# Altium schematic sheet 03_UART_232.SchDoc
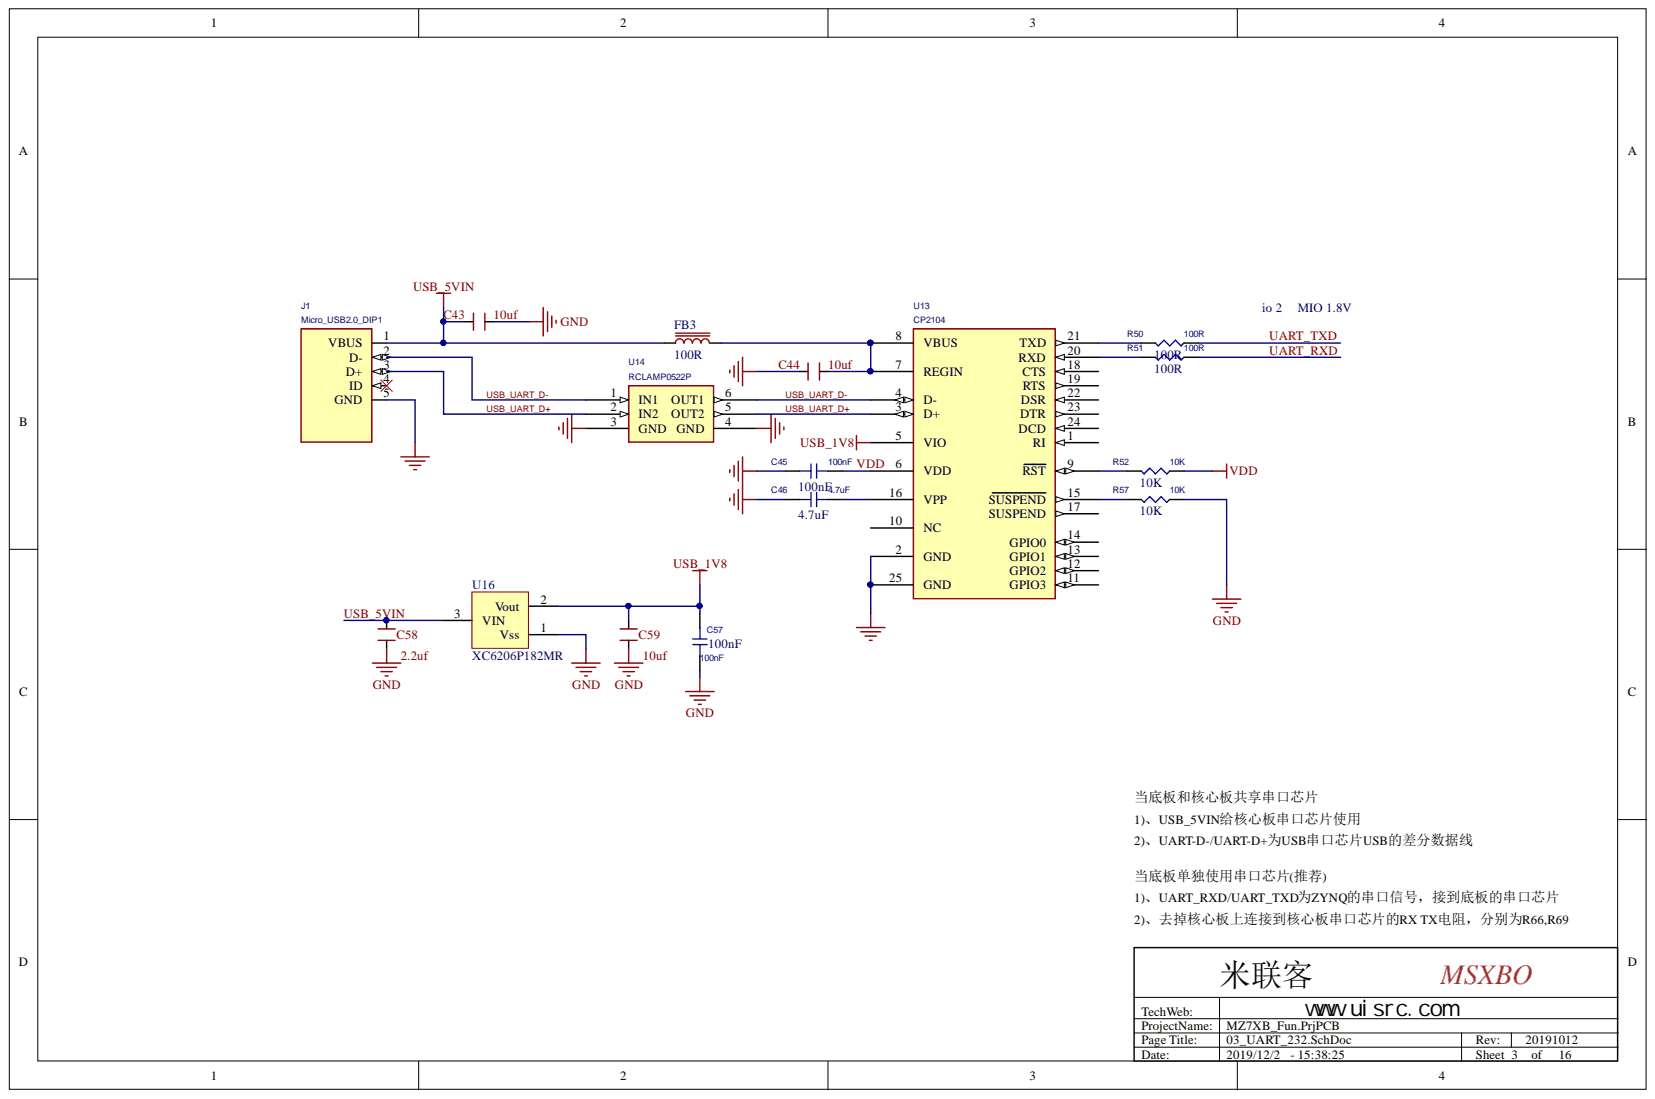
[diagram p0: 03_UART_232.SchDoc]

## Parts
C43  10uf  [CAP0603]
C44  10uf  [CAP0603]
C45  100nF  [C0603]
C46  4.7uF  [C0603]
C57  100nF  [C0603]
C58  2.2uf  [CAP0402]
C59  10uf  [CAP0603]
FB3  100R  [CAP0603]
J1  Micro_USB2.0_DIP1  [Micro_USB2_0_SMD]
R50  100R  [R0402]
R51  100R  [R0402]
R52  10K  [R0603]
R57  10K  [R0603]
U13  CP2104  [QFN24_50P4X4X1]
U14  RCLAMP0522P  [SLP1610P4_6]
U16  XC6206P182MR  [SOT23]

## Nets
GND: C43.1 C44.2 C45.1 C46.1 C57.1 C58.1 C59.1 J1.5(GND) R57.2 U13.2(GND) U13.25(GND) U14.3(GND) U14.4(GND) U16.1
USB_1V8: C57.2 C59.2 U13.5(VIO) U16.2
UART_RXD: R51.2
UART_TXD: R50.2
USB_5VIN: C43.2 C58.2 FB3.1 J1.1(VBUS) U16.3
USB_UART_D+: J1.3(D+) U13.3(D+) U14.2(IN2) U14.5(OUT2)
USB_UART_D-: J1.2(D-) U13.4(D-) U14.1(IN1) U14.6(OUT1)
VDD: C45.2 R52.2 U13.6(VDD)
NetC44_1: C44.1 FB3.2 U13.7(REGIN) U13.8(VBUS)
NetC46_2: C46.2 U13.16(VPP)
NetR50_1: R50.1 U13.21(TXD)
NetR51_1: R51.1 U13.20(RXD)
NetR52_1: R52.1 U13.9(RST)
NetR57_1: R57.1 U13.15(SUSPEND)

Not connected: J1 4(ID); U13 1(RI) 10(NC) 11(GPIO3) 12(GPIO2) 13(GPIO1) 14(GPIO0) 17(SUSPEND) 18(CTS) 19(RTS) 22(DSR) 23(DTR) 24(DCD)

## Library data of the parts
C43 C44 C58 C59 = CAPACITOR: Generic Capacitor; Component Kind: Standard; Library Name: Digilent.IntLib
C45 C46 C57 = CAP1: CURRENT: CIMAX; DIST: FLAT; KNEE: CBMAX; MAX_TEMP: CTMAX; PSpiceTemplate: C^@REFDES %1 %2 ?TOLERANCE|C^@REFDES| @VALUE ?IC/IC=@IC/ TC=@TC1,@TC2 ?TOLERANCE|\n.model C^@REFDES CAP C=1 DEV=@TOLERANCE% TC1=@TC1 TC2=@TC2 VC1=@VC1 VC2=@VC2|; SLOPE: CSMAX; TC1: 0; VOLTAGE: CMAX; Component Kind: Standard; Simulation: CAP1; TC2: 0; VC1: 0; VC2: 0
  C45 C57: Value: 100nF
  C46: Value: 4.7uF
FB3 = Ferrite Bead: Generic Ferrite Bead; Value: 600; Component Kind: Standard; Library Name: M7Z100.IntLib
J1 = Micro_USB2_0.0_DIP1: Component Kind: Standard
R50-R52 R57 = RES1: DIST: FLAT; MAX_TEMP: RTMAX; POWER: RMAX; PSpiceTemplate: R^@REFDES %1 %2 ?TOLERANCE|R^@REFDES| @VALUE TC=@TC1,@TC2 ?TOLERANCE|\n.model R^@REFDES RES R=1 DEV=@TOLERANCE% TC1=@TC1 TC2=@TC2|; SLOPE: RSMAX; TC1: 0; VOLTAGE: RVMAX; Component Kind: Standard; Simulation: RES1; TC2: 0
  R50 R51: Value: 100R
  R52 R57: Value: 10K
U16 = XC6206P332MR: Component Kind: Standard; Library Name: MIZ7035_Fun.IntLib
U13 = CP2104: Component Kind: Standard
U14 = RCLAMP0522P: Component Kind: Standard
